# Altium schematic sheet Sheet1.SchDoc
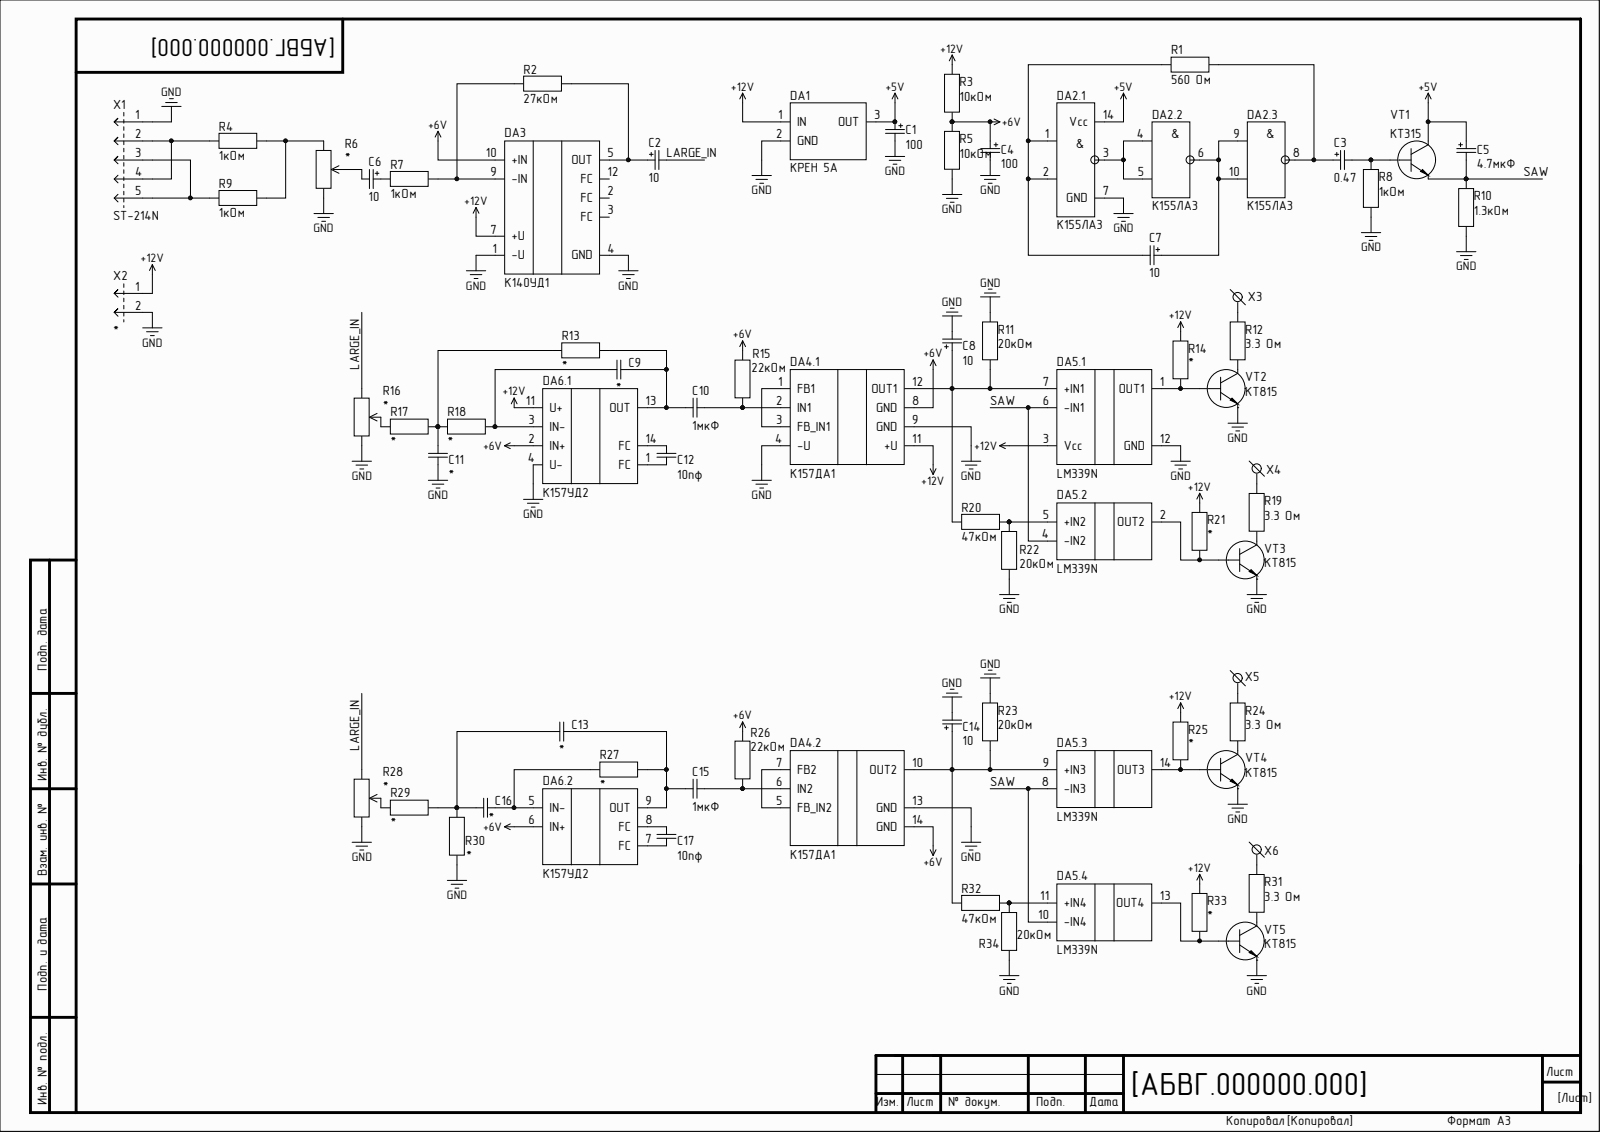
[diagram p0: Sheet1.SchDoc]

## Parts
C1  100  [C 3.5mm]
C2  10  [C 3.5mm]
C3  0.47  [C 3.5mm]
C4  100  [C 3.5mm]
C5  4.7мкФ  [C 3.5mm]
C6  10  [C 3.5mm]
C7  10  [C 3.5mm]
C8  10  [C 3.5mm]
C9  (no value)  [C 15mm]
C10  1мкФ  [C 10mm]
C11  (no value)  [C 15mm]
C12  10пф  [C 10mm]
C13  (no value)  [C 15mm]
C14  10  [C 3.5mm]
C15  1мкФ  [C 10mm]
C16  (no value)  [C 15mm]
C17  10пф  [C 10mm]
DA1  КРЕН 5А  [TO-220]
DA2  К155ЛА3  [14-LEAD DIP]  (parts .1,.2,.3)
DA3  К140УД1  [301.12-1]
DA4  К157ДА1  [14-LEAD DIP]  (parts .1,.2)
DA5  LM339N  [14-LEAD DIP]  (parts .1,.2,.3,.4)
DA6  К157УД2  [14-LEAD DIP]  (parts .1,.2)
R1  560 Ом  [R 10mm]
R2  27кОм  [R 10mm]
R3  10кОм  [R 10mm]
R4  1кОм  [R 10mm]
R5  10кОм  [R 10mm]
R6  (no value)  [OFF BOARD RESISTOR]
R7  1кОм  [R 10mm]
R8  1кОм  [R 10mm]
R9  1кОм  [R 10mm]
R10  1.3кОм  [R 10mm]
R11  20кОм  [R 10mm]
R12  3.3 Ом  [R 10mm]
R13  (no value)  [R 10mm]
R14  (no value)  [R 10mm]
R15  22кОм  [R 10mm]
R16  (no value)  [OFF BOARD RESISTOR]
R17  (no value)  [R 10mm]
R18  (no value)  [R 10mm]
R19  3.3 Ом  [R 10mm]
R20  47кОм  [R 10mm]
R21  (no value)  [R 10mm]
R22  20кОм  [R 10mm]
R23  20кОм  [R 10mm]
R24  3.3 Ом  [R 10mm]
R25  (no value)  [R 10mm]
R26  22кОм  [R 10mm]
R27  (no value)  [R 10mm]
R28  (no value)  [OFF BOARD RESISTOR]
R29  (no value)  [R 10mm]
R30  (no value)  [R 10mm]
R31  3.3 Ом  [R 10mm]
R32  47кОм  [R 10mm]
R33  (no value)  [R 10mm]
R34  20кОм  [R 10mm]
VT1  КТ315  [KT-13]
VT2  КТ815  [KT-27]
VT3  КТ815  [KT-27]
VT4  КТ815  [KT-27]
VT5  КТ815  [KT-27]
X1  ST-214N  [ST-214N]
X2  (no value)  [PLS-2]
X3  (no value)  [TP 1,4mm]
X4  (no value)  [TP 1,4mm]
X5  (no value)  [TP 1,4mm]
X6  (no value)  [TP 1,4mm]

## Nets
+5V: C1.1 C5.1 DA1.3 DA2.14(Vcc) VT1.3
+6V: C4.1 DA3.10(+IN) DA4.8(GND) DA4.14(GND) DA6.2(IN+) DA6.6(IN+) R3.1 R5.2 R15.2 R26.2
+12V: DA1.1 DA3.7(+U) DA4.11(+U) DA5.3(Vcc) DA6.11(U+) R3.2 R14.2 R21.2 R25.2 R33.2 X2.1
GND: C1.2 C4.2 C8.2 C11.1 C14.2 DA1.2 DA2.7(GND) DA3.1(-U) DA3.4(GND) DA4.4(-U) DA4.9(GND) DA4.13(GND) DA5.12(GND) DA6.4(U-) R5.1 R6.1 R8.1 R10.1 R11.2 R16.2 R22.1 R23.2 R28.2 R30.1 R34.1 VT2.2 VT3.2 VT4.2 VT5.2 X1.1 X2.2
LARGE_IN: C2.2 R16.1 R28.1
SAW: C5.2 DA5.4(-IN2) DA5.6(-IN1) DA5.8(-IN3) DA5.10(-IN4) R10.2 VT1.2
NetC2_1: C2.1 DA3.5(OUT) R2.2
NetC3_1: C3.1 DA2.8 R1.2
NetC3_2: C3.2 R8.2 VT1.1
NetC6_1: C6.1 R7.1
NetC6_2: C6.2 R6.3
NetC7_1: C7.1 DA2.6 DA2.9 DA2.10
NetC7_2: C7.2 DA2.1(&) DA2.2 R1.1
NetC8_1: C8.1 DA4.12(OUT1) DA5.7(+IN1) R11.1 R20.1
NetC9_1: C9.1 DA6.3(IN-) R18.2
NetC9_2: C9.2 C10.1 DA6.13(OUT) R13.1
NetC10_2: C10.2 DA4.2(IN1) R15.1
NetC11_2: C11.2 R13.2 R17.2 R18.1
NetC12_1: C12.1 DA6.1(FC)
NetC12_2: C12.2 DA6.14(FC)
NetC13_1: C13.1 C16.1 R29.2 R30.2
NetC13_2: C13.2 C15.1 DA6.9(OUT) R27.1
NetC14_1: C14.1 DA4.10(OUT2) DA5.9(+IN3) R23.1 R32.1
NetC15_2: C15.2 DA4.6(IN2) R26.1
NetC16_2: C16.2 DA6.5(IN-) R27.2
NetC17_1: C17.1 DA6.7(FC)
NetC17_2: C17.2 DA6.8(FC)
NetDA2_3: DA2.3 DA2.4 DA2.5
NetDA3_9: DA3.9(-IN) R2.1 R7.2
NetDA4_1: DA4.1(FB1) DA4.3(FB_IN1)
NetDA4_5: DA4.5(FB_IN2) DA4.7(FB2)
NetDA5_1: DA5.1(OUT1) R14.1 VT2.1
NetDA5_2: DA5.2(OUT2) R21.1 VT3.1
NetDA5_5: DA5.5(+IN2) R20.2 R22.2
NetDA5_11: DA5.11(+IN4) R32.2 R34.2
NetDA5_13: DA5.13(OUT4) R33.1 VT5.1
NetDA5_14: DA5.14(OUT3) R25.1 VT4.1
NetR4_1: R4.1 X1.2 X1.4
NetR4_2: R4.2 R6.2 R9.2
NetR9_1: R9.1 X1.3 X1.5
NetR12_1: R12.1 VT2.3
NetR12_2: R12.2 X3.1
NetR16_3: R16.3 R17.1
NetR19_1: R19.1 VT3.3
NetR19_2: R19.2 X4.1
NetR24_1: R24.1 VT4.3
NetR24_2: R24.2 X5.1
NetR28_3: R28.3 R29.1
NetR31_1: R31.1 VT5.3
NetR31_2: R31.2 X6.1

Not connected: DA3 2(FC) 3(FC) 12(FC)

## Library data of the parts
C1-C8 C14 = Capacitor Pol: Component Kind: Standard; Library Name: lib.IntLib
  C1 C4: Value: 100
  C2 C6-C8 C14: Value: 10
  C3: Value: 0.47
  C5: Value: 4.7мкФ
C9-C13 C15-C17 = Capacitor: Component Kind: Standard; Library Name: lib.IntLib
  C10 C15: Value: 1мкФ
  C12 C17: Value: 10пф
DA1 = KREN 5A: Component Kind: Standard; Library Name: lib.IntLib; value: КРЕН 5А
R1-R5 R7-R15 R17-R27 R29-R34 = Resistor: Component Kind: Standard; Library Name: lib.IntLib
  R1: Value: 560 Ом
  R2: Value: 27кОм
  R3 R5: Value: 10кОм
  R4 R7-R9: Value: 1кОм
  R10: Value: 1.3кОм
  R11 R22 R23 R34: Value: 20кОм
  R12 R19 R24 R31: Value: 3.3 Ом
  R15 R26: Value: 22кОм
  R20 R32: Value: 47кОм
R6 R16 R28 = ResistorVar: Component Kind: Standard; Library Name: lib.IntLib
VT1-VT5 = NPN: Transistor; Component Kind: Standard; Library Name: lib.IntLib
  VT1: Value: КТ315
  VT2-VT5: Value: КТ815
X1 = ST-214N: Connector; Component Kind: Standard; Library Name: lib.IntLib; value: ST-214N
X2 = Connector 2-pin: Connector; Component Kind: Standard; Library Name: lib.IntLib
X3-X6 = TP: Component Kind: Standard; Library Name: lib.IntLib
DA2 = К155ЛА3: Component Kind: Standard; Library Name: lib.IntLib; value: К155ЛА3
DA3 = К140УД1: Component Kind: Standard; Library Name: lib.IntLib; value: К140УД1
DA4 = К157ДА1: Component Kind: Standard; Library Name: lib.IntLib; value: К157ДА1
DA5 = LM339N: Component Kind: Standard; Library Name: lib.IntLib; value: LM339N
DA6 = К157УД2: Component Kind: Standard; Library Name: lib.IntLib; value: К157УД2
